# Altium schematic sheet Output_Terminals.SchDoc("Output terminals")
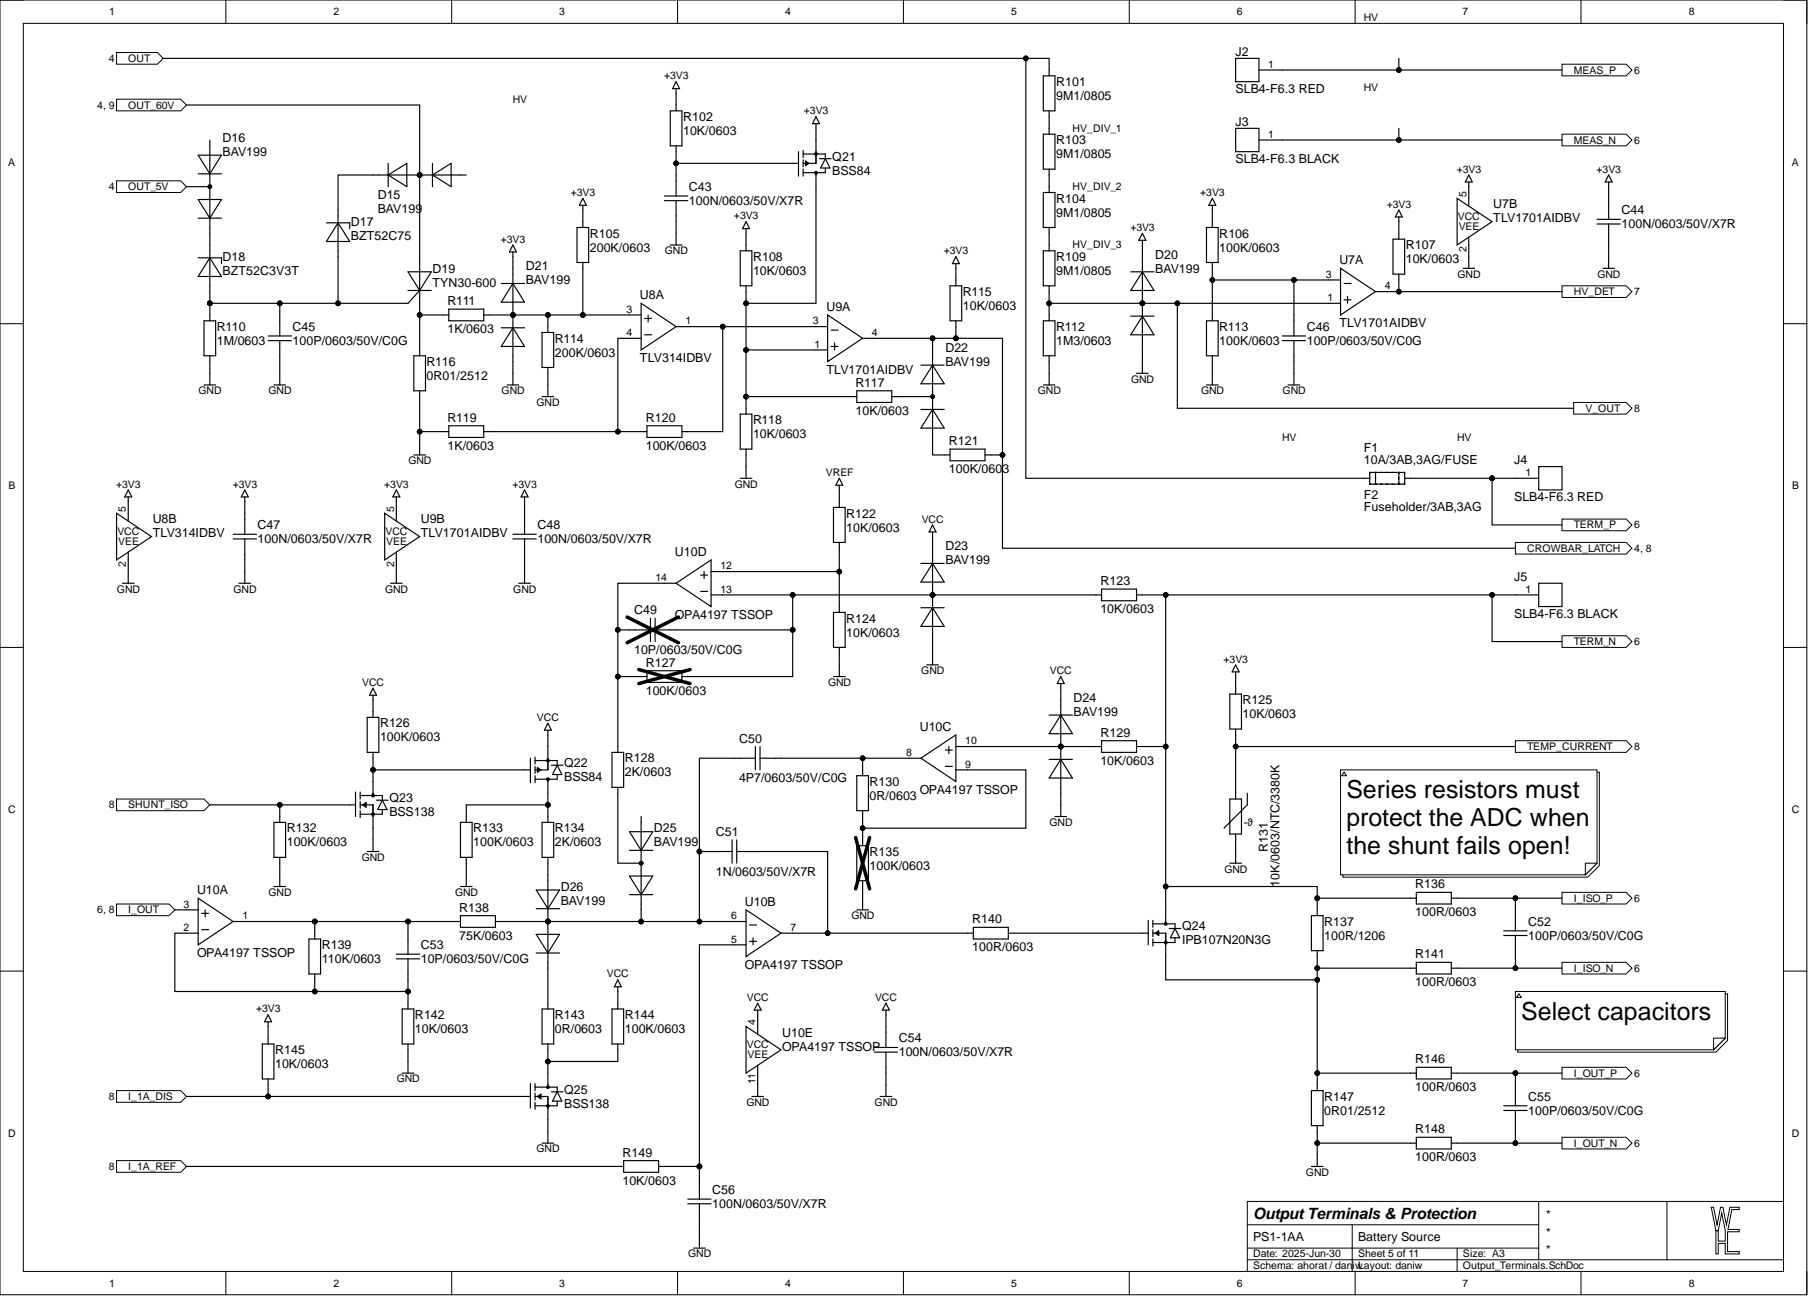
[diagram p0: Output_Terminals.SchDoc]

## Parts
C43  100N/0603/50V/X7R  [CAP0603_X7R]
C44  100N/0603/50V/X7R  [CAP0603_X7R]
C45  100P/0603/50V/C0G  [CAP0603_C0G]
C46  100P/0603/50V/C0G  [CAP0603_C0G]
C47  100N/0603/50V/X7R  [CAP0603_X7R]
C48  100N/0603/50V/X7R  [CAP0603_X7R]
C49  10P/0603/50V/C0G  [CAP0603_C0G]
C50  4P7/0603/50V/C0G  [CAP0603_C0G]
C51  1N/0603/50V/X7R  [CAP0603_X7R]
C52  100P/0603/50V/C0G  [CAP0603_C0G]
C53  10P/0603/50V/C0G  [CAP0603_C0G]
C54  100N/0603/50V/X7R  [CAP0603_X7R]
C55  100P/0603/50V/C0G  [CAP0603_C0G]
C56  100N/0603/50V/X7R  [CAP0603_X7R]
D15  BAV199  [SOT2395P280X125-3-SE]
D16  BAV199  [SOT2395P280X125-3-SE]
D17  BZT52C75  [SOD3718X125]
D18  BZT52C3V3T  [SOD1608X065]
D19  TYN30-600  [TO220-3]
D20  BAV199  [SOT2395P280X125-3-SE]
D21  BAV199  [SOT2395P280X125-3-SE]
D22  BAV199  [SOT2395P280X125-3-SE]
D23  BAV199  [SOT2395P280X125-3-SE]
D24  BAV199  [SOT2395P280X125-3-SE]
D25  BAV199  [SOT2395P280X125-3-SE]
D26  BAV199  [SOT2395P280X125-3-SE]
F1  10A/3AB,3AG/FUSE
F2  Fuseholder/3AB,3AG  [03540101ZXGY]
J2  SLB4-F6.3 RED  [SLB4-F6.3-RED]
J3  SLB4-F6.3 BLACK  [SLB4-F6.3-BLACK]
J4  SLB4-F6.3 RED  [SLB4-F6.3-RED]
J5  SLB4-F6.3 BLACK  [SLB4-F6.3-BLACK]
Q21  BSS84  [SOT2395P280X125-3-SE]
Q22  BSS84  [SOT2395P280X125-3-SE]
Q23  BSS138  [SOT2395P280X125-3-SE]
Q24  IPB107N20N3G  [TO254P1530X1035-3]
Q25  BSS138  [SOT2395P280X125-3-SE]
R101  9M1/0805  [R0805-0.50-WW]
R102  10K/0603  [R0603-0.40-WW]
R103  9M1/0805  [R0805-0.50-WW]
R104  9M1/0805  [R0805-0.50-WW]
R105  200K/0603  [R0603-0.40-WW]
R106  100K/0603  [R0603-0.40-WW]
R107  10K/0603  [R0603-0.40-WW]
R108  10K/0603  [R0603-0.40-WW]
R109  9M1/0805  [R0805-0.50-WW]
R110  1M/0603  [R0603-0.40-WW]
R111  1K/0603  [R0603-0.40-WW]
R112  1M3/0603  [R0603-0.40-WW]
R113  100K/0603  [R0603-0.40-WW]
R114  200K/0603  [R0603-0.40-WW]
R115  10K/0603  [R0603-0.40-WW]
R116  0R01/2512  [R2512-0.65-WW]
R117  10K/0603  [R0603-0.40-WW]
R118  10K/0603  [R0603-0.40-WW]
R119  1K/0603  [R0603-0.40-WW]
R120  100K/0603  [R0603-0.40-WW]
R121  100K/0603  [R0603-0.40-WW]
R122  10K/0603  [R0603-0.40-WW]
R123  10K/0603  [R0603-0.40-WW]
R124  10K/0603  [R0603-0.40-WW]
R125  10K/0603  [R0603-0.40-WW]
R126  100K/0603  [R0603-0.40-WW]
R127  100K/0603  [R0603-0.40-WW]
R128  2K/0603  [R0603-0.40-WW]
R129  10K/0603  [R0603-0.40-WW]
R130  0R/0603  [R0603-0.40-WW]
R131  10K/0603/NTC/3380K  [R0603-0.40-WW]
R132  100K/0603  [R0603-0.40-WW]
R133  100K/0603  [R0603-0.40-WW]
R134  2K/0603  [R0603-0.40-WW]
R135  100K/0603  [R0603-0.40-WW]
R136  100R/0603  [R0603-0.40-WW]
R137  100R/1206  [R1206-0.80-WW]
R137
R138  75K/0603  [R0603-0.40-WW]
R139  110K/0603  [R0603-0.40-WW]
R140  100R/0603  [R0603-0.40-WW]
R141  100R/0603  [R0603-0.40-WW]
R142  10K/0603  [R0603-0.40-WW]
R143  0R/0603  [R0603-0.40-WW]
R144  100K/0603  [R0603-0.40-WW]
R145  10K/0603  [R0603-0.40-WW]
R146  100R/0603  [R0603-0.40-WW]
R147  0R01/2512  [R2512-0.65-WW]
R148  100R/0603  [R0603-0.40-WW]
R149  10K/0603  [R0603-0.40-WW]
U7  TLV1701AIDBV  [SOT2395P280X125-5-NW]  (parts A,B)
U8  TLV314IDBV  [SOT2395P280X125-5-NW]  (parts A,B)
U9  TLV1701AIDBV  [SOT2395P280X125-5-NW]  (parts A,B)
U10  OPA4197 TSSOP  [SOP065P640X120-14NW]  (parts A,B,C,D,E)

## Ports
CROWBAR_LATCH, HV_DET, I_1A_DIS, I_1A_REF, I_ISO_N, I_ISO_P, I_OUT, I_OUT_N, I_OUT_P, MEAS_N, MEAS_P, OUT, OUT_5V, OUT_60V, SHUNT_ISO, TEMP_CURRENT, TERM_N, TERM_P, V_OUT

## Nets
+3V3: C44.1 C47.1 C48.1 D20.2 D21.2 Q21.2 R102.1 R105.1 R106.1 R107.1 R108.1 R115.2 R125.1 R145.1 U7.5 U8.5 U9.5
GND: C43.2 C44.2 C45.2 C46.2 C47.2 C48.2 C54.2 C56.2 D20.1 D21.1 D23.1 D24.1 Q23.2 Q25.2 R110.2 R112.2 R113.2 R114.2 R116.2 R118.2 R119.2 R124.2 R131.2 R132.2 R133.2 R135.2 R142.2 R147.2 R148.1 U7.2 U8.2 U9.2 U10.11
VCC: C54.1 D23.2 D24.2 Q22.2 R126.1 R144.1 U10.4
VREF: R122.1
CROWBAR_LATCH: D22.2 R115.1 R121.2 U9.4 ; port CROWBAR_LATCH
HV_DET: R107.2 U7.4 ; port HV_DET
I_1A_DIS: Q25.1 R145.2 ; port I_1A_DIS
I_1A_REF: R149.1 ; port I_1A_REF
I_ISO_N: C52.2 R141.2 ; port I_ISO_N
I_ISO_P: C52.1 R136.2 ; port I_ISO_P
I_OUT: U10.3 ; port I_OUT
I_OUT_N: C55.2 R148.2 ; port I_OUT_N
I_OUT_P: C55.1 R146.2 ; port I_OUT_P
MEAS_N: J3.1 ; port MEAS_N
MEAS_P: J2.1 ; port MEAS_P
OUT: F2.1 R101.1 ; port OUT
OUT_5V: D16.3 ; port OUT_5V
OUT_60V: D15.3 D19.2 ; port OUT_60V
SHUNT_ISO: Q23.1 R132.1 ; port SHUNT_ISO
TEMP_CURRENT: R125.2 R131.1 ; port TEMP_CURRENT
TERM_N: J5.1 Q24.3 R123.2 R129.2 R136.1 R137.1 ; port TERM_N
TERM_P: F2.2 J4.1 ; port TERM_P
V_OUT: D20.3 R109.2 R112.1 U7.1 ; port V_OUT
NetC43_1: C43.1 Q21.1 R102.2
NetC45_1: C45.1 D17.2 D18.2 D19.3 R110.1
NetC46_1: C46.1 R106.2 R113.1 U7.3
NetC49_1: C49.1 R127.1 R128.1 U10.14
NetC49_2: C49.2 D23.3 R123.1 R127.2 U10.13
NetC50_1: C50.1 C51.1 D25.2 D26.3 R138.2 U10.6
NetC50_2: C50.2 R130.1 U10.8
NetC51_2: C51.2 R140.1 U10.7
NetC53_1: C53.1 R138.1 R139.1 U10.1
NetC53_2: C53.2 R139.2 R142.1 U10.2
NetC56_1: C56.1 R149.2 U10.5
NetD15_1: D15.1
NetD15_2: D15.2 D17.1
NetD16_1: D16.1
NetD16_2: D16.2 D18.1
NetD19_1: D19.1 R111.1 R116.1
NetD21_3: D21.3 R105.2 R111.2 R114.1 U8.3
NetD22_1: D22.1 R121.1
NetD22_3: D22.3 R117.2
NetD24_3: D24.3 R129.1 U10.10
NetD25_1: D25.1
NetD25_3: D25.3 R128.2
NetD26_1: D26.1 R134.2
NetD26_2: D26.2 R143.1
NetQ21_3: Q21.3 R108.2 R117.1 R118.1 U9.1
NetQ22_1: Q22.1 Q23.3 R126.2
NetQ22_3: Q22.3 R133.1 R134.1
NetQ24_1: Q24.1 R140.2
NetQ24_2: Q24.2 R137.2 R141.1 R146.1 R147.1
NetQ25_3: Q25.3 R143.2 R144.2
NetR101_2: R101.2 R103.1
NetR103_2: R103.2 R104.1
NetR104_2: R104.2 R109.1
NetR119_1: R119.1 R120.2 U8.4
NetR120_1: R120.1 U8.1 U9.3
NetR122_2: R122.2 R124.1 U10.12
NetR130_2: R130.2 R135.1 U10.9

## Library data of the parts
C43 C44 C47 C48 C54 C56 = 100N/0603/50V/X7R: CAP SM 0603 100nF 50V X7R; Manufacturer: GENERIC; Manufacturer 1: YAGEO; Manufacturer Part Number 1: CC0603KPX7R9BB104; Absolute Value: 1E-7; Database Table Name: C$; Eurocircuits GPN: GPC0603104; Component Kind: Standard; HelpURL: https://www.yageo.com/upload/media/product/app/datasheet/mlcc/upy-gphc_x7r_6_3v-to-250v.pdf; JLC Type: Basic; Manufacturer 2: YAGEO; Manufacturer Part Number 2: CC0603MRX7R9BB104; Manufacturer Part Number 3: CC0603KRX7R9BB104; Package: 0603; Part Number: 100N/0603/50V/X7R; Supplier 1: Digi-Key; Supplier 2: Digi-Key; Supplier 3: LCSC; Supplier Part Number 1: 311-3567-1-ND; Supplier Part Number 2: 311-4110-1-ND; Supplier Part Number 3: C14663; Value: 100nF; Library Name: daniw_altium_lib.DbLib
C45 C46 C52 C55 = 100P/0603/50V/C0G: CAP SM 0603 100pF 50V C0G; Manufacturer: GENERIC; Manufacturer 1: YAGEO; Manufacturer Part Number 1: CC0603JRNPO9BN101; Absolute Value: 1E-10; Database Table Name: C$; Eurocircuits GPN: GPC0603101-C0G; Component Kind: Standard; HelpURL: https://www.yageo.com/upload/media/product/productsearch/datasheet/mlcc/UPY-GP_NP0_16V-to-50V_18.pdf; JLC Type: Basic; Manufacturer 2: YAGEO; Manufacturer Part Number 2: CC0603KRNPO9BN101; Manufacturer Part Number 3: CL10C101JB8NNNC; Package: 0603; Part Number: 100P/0603/50V/C0G; Supplier 1: Digi-Key; Supplier 2: Digi-Key; Supplier 3: LCSC; Supplier Part Number 1: 311-1069-1-ND; Supplier Part Number 2: 311-3600-1-ND; Supplier Part Number 3: C14858; Value: 100pF; Library Name: daniw_altium_lib.DbLib
C49 C53 = 10P/0603/50V/C0G: CAP SM 0603 10pF 50V C0G; Manufacturer: GENERIC; Manufacturer 1: YAGEO; Manufacturer Part Number 1: CC0603JRNPO9BN100; Absolute Value: 1E-11; Database Table Name: C$; Eurocircuits GPN: GPC0603100-C0G; Component Kind: Standard; HelpURL: https://www.yageo.com/upload/media/product/productsearch/datasheet/mlcc/UPY-GP_NP0_16V-to-50V_18.pdf; Manufacturer 2: YAGEO; Manufacturer Part Number 2: CC0603KRNPO9BN100; Manufacturer Part Number 3: CL10C100JB8NNNC; Package: 0603; Part Number: 10P/0603/50V/C0G; Supplier 1: Digi-Key; Supplier 2: Digi-Key; Supplier 3: LCSC; Supplier Part Number 1: 311-1058-1-ND; Supplier Part Number 2: 311-4025-1-ND; Supplier Part Number 3: C1634; Value: 10pF; Library Name: daniw_altium_lib.DbLib
C50 = 4P7/0603/50V/C0G: CAP SM 0603 4.7pF 50V C0G; Manufacturer: GENERIC; Manufacturer 1: YAGEO; Manufacturer Part Number 1: CC0603CRNPO9BN4R7; Absolute Value: 4.7E-12; Database Table Name: C$; Eurocircuits GPN: GPC06034R7-C0G; Component Kind: Standard; HelpURL: https://www.yageo.com/upload/media/product/productsearch/datasheet/mlcc/UPY-GP_NP0_16V-to-50V_18.pdf; Manufacturer 2: YAGEO; Manufacturer Part Number 2: CC0603DRNPO9BN4R7; Manufacturer Part Number 3: CGA0603C0G4R7C500JT; Package: 0603; Part Number: 4P7/0603/50V/C0G; Supplier 1: Digi-Key; Supplier 2: Digi-Key; Supplier 3: LCSC; Supplier Part Number 1: 311-1740-1-ND; Supplier Part Number 2: 13-CC0603DRNPO9BN4R7CT-ND; Supplier Part Number 3: C41361238; Value: 4.7pF; Library Name: daniw_altium_lib.DbLib
C51 = 1N/0603/50V/X7R: CAP SM 0603 1.0nF 50V X7R; Manufacturer: GENERIC; Manufacturer 1: YAGEO; Manufacturer Part Number 1: CC0603KPX7R9BB102; Absolute Value: 1E-9; Database Table Name: C$; Eurocircuits GPN: GPC0603102; Component Kind: Standard; HelpURL: https://www.yageo.com/upload/media/product/app/datasheet/mlcc/upy-gphc_x7r_6_3v-to-250v.pdf; JLC Type: Basic; Manufacturer 2: YAGEO; Manufacturer Part Number 2: CC0603KRX7R9BB102; Manufacturer Part Number 3: CL10B102KB8NNNC; Package: 0603; Part Number: 1N/0603/50V/X7R; Supplier 1: Digi-Key; Supplier 2: Digi-Key; Supplier 3: LCSC; Supplier Part Number 1: 13-CC0603KPX7R9BB102CT-ND; Supplier Part Number 2: 311-1080-1-ND; Supplier Part Number 3: C1588; Value: 1.0nF; Library Name: daniw_altium_lib.DbLib
D15 D16 D20-D26 = BAV199: Silicon Low Leakage Diode 85V; Manufacturer: Infineon Technologies; Manufacturer Part Number 1: BAV199E6433HTMA1; Database Table Name: D$; Absolute Value: 85V; Component Kind: Standard; HelpURL: https://www.infineon.com/dgdl/bav199series.pdf?folderId=db3a30431400ef6801141c748874044e&fileId=db3a30431400ef6801141cba733104e5; Manufacturer 1: Infineon Technologies; Manufacturer 3: LRC; Manufacturer Part Number 3: LBAV199LT1G; Package: SOT23; Part Number: BAV199; Supplier 1: Digikey; Supplier 3: LCSC; Supplier Part Number 1: BAV199E6433HTMA1CT-ND; Supplier Part Number 3: C12740; Value: BAV199; Library Name: daniw_altium_lib.DbLib
D17 = BZT52C75: DIODE ZENER 74.5V 350MW SOD123; Manufacturer: Nexperia USA Inc.; Manufacturer Part Number 1: BZT52-C75J; Database Table Name: D$; Absolute Value: 74.5 V; Component Kind: Standard; HelpURL: https://assets.nexperia.com/documents/data-sheet/BZT52_SER.pdf; Manufacturer 1: Nexperia USA Inc.; Manufacturer 2: Panjit International Inc.; Manufacturer Part Number 2: BZT52-C75-AU_R1_000A1; Package: SOD-123; Part Number: BZT52C75; Supplier 1: Digikey; Supplier 2: Digikey; Supplier Part Number 1: 1727-8146-1-ND; Supplier Part Number 2: 3757-BZT52-C75-AU_R1_000A1CT-ND; Value: BZT52C75; Library Name: daniw_altium_lib.DbLib
D18 = BZT52C3V3T: DIODE ZENER 3.3V 300MW SOD523; Manufacturer: Diodes Incorporated; Manufacturer Part Number 1: BZT52C3V3T-7; Database Table Name: D$; Absolute Value: 3.3 V; Component Kind: Standard; HelpURL: https://www.diodes.com/assets/Datasheets/ds30502.pdf; Manufacturer 1: Diodes Incorporated; Manufacturer 2: Micro Commercial Co; Manufacturer 3: BORN; Manufacturer Part Number 2: BZT52C3V3T-TP; Manufacturer Part Number 3: BZT52C3V3T; Package: SC-79, SOD-523; Part Number: BZT52C3V3T; Supplier 1: Digikey; Supplier 2: Digikey; Supplier 3: LCSC; Supplier Part Number 1: BZT52C3V3T-7DICT-ND; Supplier Part Number 2: BZT52C3V3T-TPMSCT-ND; Supplier Part Number 3: C20612286; Value: BZT52C3V3T; Library Name: daniw_altium_lib.DbLib
D19 = TYN30-600: Planar passivated Silicon Controlled Rectifier (SCR) in a TO220 plastic package, 600V, 30A; Manufacturer: WeEn Semiconductors; Manufacturer Part Number 1: TYN30-600TFQ; Database Table Name: D$; Value: TYN30-600TFQ; Component Kind: Standard; HelpURL: https://www.ween-semi.com/sites/default/files/2022-02/TYN30-600TF.pdf; Manufacturer 1: WeEn Semiconductors; Package: TO-220; Part Number: TYN30-600; Supplier 1: Digikey; Supplier Part Number 1: 1740-TYN30-600TFQ-ND; Library Name: daniw_altium_lib.DbLib
F1 = 10A/3AB,3AG/FUSE: Fuse 600V AC/DC 3AB, 3AG; Manufacturer: Belfuse; Manufacturer 1: Bel Fuse Inc.; Manufacturer Part Number 1: 0ADEC9100-BE; Database Table Name: R$; Value: 10A; Component Kind: Standard; HelpURL: https://www.belfuse.com/resources/datasheets/circuitprotection/ds-cp-0adex-series.pdf; Package: 3AB,3AG; Part Number: 10A/3AB,3AG/FUSE; Supplier 1: Digi-Key; Supplier Part Number 1: 5923-0ADEC9100-BE-ND; Library Name: daniw_altium_lib.DbLib
F2 = Fuseholder/3AB,3AG: 354 Series OMNI-BLOK® Molded Base Fuse Block for 3AB/3AG Fuses; Manufacturer: Littelfuse; Manufacturer 1: Littelfuse Inc.; Database Table Name: R$; Component Kind: Standard; HelpURL: https://www.littelfuse.com/assetdocs/littelfuse_fuse_block_354_datasheet.pdf?assetguid=6e94c133-ad48-47b7-8fff-80ea6c66704d; Manufacturer Part Number 1: 03540101ZXGY; Package: 3AB,3AG; Part Number: Fuseholder/3AB,3AG; Supplier 1: Digi-Key; Supplier Part Number 1: F1498-ND; Library Name: daniw_altium_lib.DbLib
J2 J4 = SLB4-F6.3 RED: SLB4-F6.3 Insulated, rigid, Ø 4 mm, accepting spring-loaded Ø 4 mm plugs with rigid insulating sleeve. Machined brass. Insulation material UL94 V-0. The socket is screwed into pre-drilled panels of plastic, metal, etc. Flat connecting tab 6.3 mm x 0.8 mm.; Manufacturer: Stäubli; Manufacturer 1: Stäubli Electrical Connectors; Manufacturer Part Number 1: 23.3060-22; Database Table Name: CON$; System: Connector; Component Kind: Standard; HelpURL: https://www.staubli.com/content/dam/ecs/technical-documentation/datasheets/TM/23.3060_en.pdf; Part Number: SLB4-F6.3 RED; Supplier 1: Distrelec; Supplier Part Number 1: 30136218; Library Name: daniw_altium_lib.DbLib
J3 J5 = SLB4-F6.3 BLACK: SLB4-F6.3 Insulated, rigid, Ø 4 mm, accepting spring-loaded Ø 4 mm plugs with rigid insulating sleeve. Machined brass. Insulation material UL94 V-0. The socket is screwed into pre-drilled panels of plastic, metal, etc. Flat connecting tab 6.3 mm x 0.8 mm.; Manufacturer: Stäubli; Manufacturer 1: Stäubli Electrical Connectors; Manufacturer Part Number 1: 23.3060-21; Database Table Name: CON$; System: Connector; Component Kind: Standard; HelpURL: https://www.staubli.com/content/dam/ecs/technical-documentation/datasheets/TM/23.3060_en.pdf; Part Number: SLB4-F6.3 BLACK; Supplier 1: Distrelec; Supplier Part Number 1: 30136217; Library Name: daniw_altium_lib.DbLib
Q21 Q22 = BSS84: 50V P-Channel MOSFET; Manufacturer: GENERIC; Manufacturer 1: Good-Ark Semiconductor; Database Table Name: T$; Component Kind: Standard; HelpURL: https://goodarksemi.com/docs/datasheets/mosfets/BSS84.pdf; JLC Type: Extended; Manufacturer 2: LRC; Manufacturer 3: Jiangsu Changjing Electronics Technology Co., Ltd.; Manufacturer Part Number 1: BSS84; Manufacturer Part Number 2: LBSS84LT1G; Manufacturer Part Number 3: BSS84; Package: SOT23; Part Number: BSS84; Supplier 1: Digi-Key; Supplier 2: LCSC; Supplier 3: LCSC; Supplier Part Number 1: 4786-BSS84CT-ND; Supplier Part Number 2: C8492; Supplier Part Number 3: C114481; Library Name: daniw_altium_lib.DbLib
Q23 Q25 = BSS138: N-Kanal 50 V 220mA (Ta) 350mW (Ta) Oberflächenmontage SOT-23; Manufacturer: GENERIC; Manufacturer 1: ANBON SEMICONDUCTOR (INT'L) LIMITED; Database Table Name: T$; Component Kind: Standard; HelpURL: https://mm.digikey.com/Volume0/opasdata/d220001/medias/docus/3037/BSS138.pdf; JLC Type: Extended; Manufacturer 2: FOSAN; Manufacturer 3: FOSAN; Manufacturer Part Number 1: BSS138; Manufacturer Part Number 2: BSS138; Manufacturer Part Number 3: BSS138; Package: SOT23; Part Number: BSS138; Supplier 1: Digi-Key; Supplier 2: LCSC; Supplier 3: LCSC; Supplier Part Number 1: 4530-BSS138CT-ND; Supplier Part Number 2: C2926186; Supplier Part Number 3: C2926186; Library Name: daniw_altium_lib.DbLib
Q24 = IPB107N20N3G: 200V 88A 300W 10.7mΩ@10V,88A 4V 1 N-channel TO-263-3 MOSFETs ROHS; Manufacturer: Infineon Technologies; Manufacturer Part Number 1: IPB107N20N3GATMA1CT-ND; Database Table Name: T$; Component Kind: Standard; HelpURL: https://www.infineon.com/dgdl/IPP_I_110N20N3+G_IPB107N20N3+G+Rev2.2.pdf?folderId=db3a3043163797a6011637d4bae7003b&fileId=db3a3043243b5f170124968e7d1f18e7; Manufacturer 1: Infineon Technologies; Manufacturer 3: Infineon Technologies; Manufacturer Part Number 3: IPB107N20N3G; Package: TO-263; Part Number: IPB107N20N3G; Supplier 1: Digi-Key; Supplier 3: LCSC; Supplier Part Number 1: IPB107N20N3GATMA1; Supplier Part Number 3: C444895; Library Name: daniw_altium_lib.DbLib
R101 R103 R104 R109 = 9M1/0805: RES SM 0805 9M1; Manufacturer: GENERIC; Manufacturer 1: YAGEO; Manufacturer Part Number 1: RC0805FR-079M1L; Absolute Value: 9100000; Database Table Name: R$; Eurocircuits GPN: GPR08059M1; Component Kind: Standard; HelpURL: https://www.yageo.com/upload/media/product/productsearch/datasheet/rchip/PYu-RC_Group_51_RoHS_L_12.pdf; Manufacturer 3: FOJAN; Manufacturer Part Number 3: FRC0805J915TS; Package: 0805; Part Number: 9M1/0805; Supplier 1: Digi-Key; Supplier 3: LCSC; Supplier Part Number 1: 13-RC0805FR-079M1LCT-ND; Supplier Part Number 3: C2930318; Value: 9M1; Library Name: daniw_altium_lib.DbLib
R102 R107 R108 R115 R117 R118 R122-R125 R129 R142 R145 R149 = 10K/0603: RES SM 0603 10K; Manufacturer: GENERIC; Manufacturer 1: YAGEO; Manufacturer Part Number 1: RC0603FR-1310KL; Absolute Value: 10000; Database Table Name: R$; Eurocircuits GPN: GPR060310K; Component Kind: Standard; HelpURL: https://www.yageo.com/upload/media/product/productsearch/datasheet/rchip/PYu-RC_Group_51_RoHS_L_12.pdf; JLC Type: Basic; Manufacturer 2: YAGEO; Manufacturer 3: UNI-ROYAL(Uniroyal Elec); Manufacturer Part Number 2: RC0603FR-1010KL; Manufacturer Part Number 3: 0603WAF1002T5E; Package: 0603; Part Number: 10K/0603; Supplier 1: Digi-Key; Supplier 2: Digi-Key; Supplier 3: LCSC; Supplier Part Number 1: 13-RC0603FR-1310KLCT-ND; Supplier Part Number 2: 13-RC0603FR-1010KLCT-ND; Supplier Part Number 3: C25804; Value: 10K; Library Name: daniw_altium_lib.DbLib
R105 R114 = 200K/0603: RES SM 0603 200K; Manufacturer: GENERIC; Manufacturer 1: YAGEO; Manufacturer Part Number 1: RC0603FR-13200KL; Absolute Value: 200000; Database Table Name: R$; Eurocircuits GPN: GPR0603200K; Component Kind: Standard; HelpURL: https://www.yageo.com/upload/media/product/app/datasheet/rchip/pyu-rc_group_51_rohs_l.pdf; JLC Type: Basic; Manufacturer 2: YAGEO; Manufacturer 3: UNI-ROYAL(Uniroyal Elec); Manufacturer Part Number 2: RC0603FR-10200KL; Manufacturer Part Number 3: 0603WAF2003T5E; Package: 0603; Part Number: 200K/0603; Supplier 1: Digi-Key; Supplier 2: Digi-Key; Supplier 3: LCSC; Supplier Part Number 1: 13-RC0603FR-13200KLCT-ND; Supplier Part Number 2: 13-RC0603FR-10200KLCT-ND; Supplier Part Number 3: C25811; Value: 200K; Library Name: daniw_altium_lib.DbLib
R106 R113 R120 R121 R126 R127 R132 R133 R135 R144 = 100K/0603: RES SM 0603 100K; Manufacturer: GENERIC; Manufacturer 1: YAGEO; Manufacturer Part Number 1: RC0603FR-13100KL; Absolute Value: 100000; Database Table Name: R$; Eurocircuits GPN: GPR0603100K; Component Kind: Standard; HelpURL: https://www.yageo.com/upload/media/product/productsearch/datasheet/rchip/PYu-RC_Group_51_RoHS_L_12.pdf; JLC Type: Basic; Manufacturer 2: YAGEO; Manufacturer 3: UNI-ROYAL(Uniroyal Elec); Manufacturer Part Number 2: RC0603FR-10100KL; Manufacturer Part Number 3: 0603WAF1003T5E; Package: 0603; Part Number: 100K/0603; Supplier 1: Digi-Key; Supplier 2: Digi-Key; Supplier 3: LCSC; Supplier Part Number 1: 13-RC0603FR-13100KLCT-ND; Supplier Part Number 2: 13-RC0603FR-10100KLCT-ND; Supplier Part Number 3: C25803; Value: 100K; Library Name: daniw_altium_lib.DbLib
R110 = 1M/0603: RES SM 0603 1M; Manufacturer: GENERIC; Manufacturer 1: YAGEO; Manufacturer Part Number 1: RC0603FR-131ML; Absolute Value: 1000000; Database Table Name: R$; Eurocircuits GPN: GPR06031M; Component Kind: Standard; HelpURL: https://www.yageo.com/upload/media/product/app/datasheet/rchip/pyu-rc_group_51_rohs_l.pdf; JLC Type: Basic; Manufacturer 2: YAGEO; Manufacturer 3: UNI-ROYAL(Uniroyal Elec); Manufacturer Part Number 2: RC0603FR-101ML; Manufacturer Part Number 3: 0603WAF1004T5E; Package: 0603; Part Number: 1M/0603; Supplier 1: Digi-Key; Supplier 2: Digi-Key; Supplier 3: LCSC; Supplier Part Number 1: 13-RC0603FR-131MLCT-ND; Supplier Part Number 2: 13-RC0603FR-101MLCT-ND; Supplier Part Number 3: C22935; Value: 1M; Library Name: daniw_altium_lib.DbLib
R111 R119 = 1K/0603: RES SM 0603 1K; Manufacturer: GENERIC; Manufacturer 1: YAGEO; Manufacturer Part Number 1: RC0603FR-131KL; Absolute Value: 1000; Database Table Name: R$; Eurocircuits GPN: GPR06031K; Component Kind: Standard; HelpURL: https://www.yageo.com/upload/media/product/app/datasheet/rchip/pyu-rc_group_51_rohs_l.pdf; JLC Type: Basic; Manufacturer 2: YAGEO; Manufacturer 3: UNI-ROYAL(Uniroyal Elec); Manufacturer Part Number 2: RC0603FR-101KL; Manufacturer Part Number 3: 0603WAF1001T5E; Package: 0603; Part Number: 1K/0603; Supplier 1: Digi-Key; Supplier 2: Digi-Key; Supplier 3: LCSC; Supplier Part Number 1: 13-RC0603FR-131KLCT-ND; Supplier Part Number 2: 13-RC0603FR-101KLCT-ND; Supplier Part Number 3: C21190; Value: 1K; Library Name: daniw_altium_lib.DbLib
R112 = 1M3/0603: RES SM 0603 1M3; Manufacturer: GENERIC; Manufacturer 1: YAGEO; Manufacturer Part Number 1: RC0603FR-071M3L; Absolute Value: 1300000; Database Table Name: R$; Eurocircuits GPN: GPR06031M3; Component Kind: Standard; HelpURL: https://www.yageo.com/upload/media/product/app/datasheet/rchip/pyu-rc_group_51_rohs_l.pdf; Manufacturer 3: FOJAN; Manufacturer Part Number 3: FRC0603J135; Package: 0603; Part Number: 1M3/0603; Supplier 1: Digi-Key; Supplier 3: LCSC; Supplier Part Number 1: YAG3326CT-ND; Supplier Part Number 3: C2907082; Value: 1M3; Library Name: daniw_altium_lib.DbLib
R116 R147 = 0R01/2512: RES SM 2512 0R01; Manufacturer: GENERIC; Manufacturer 1: YAGEO; Manufacturer Part Number 1: PE2512FKE7W0R01L; Absolute Value: 0.01; Database Table Name: R$; Component Kind: Standard; HelpURL: https://www.yageo.com/upload/media/product/products/datasheet/rchip/PYu-PE_521_RoHS_L_13.pdf; Manufacturer 3: prosemi; Manufacturer Part Number 3: LMJ25MF2P0R010; Package: 2512; Part Number: 0R01/2512; Supplier 1: Digi-Key; Supplier 3: LCSC; Supplier Part Number 1: YAG2167CT-ND; Supplier Part Number 3: C2838941; Value: 0R01; Library Name: daniw_altium_lib.DbLib
R128 R134 = 2K/0603: RES SM 0603 2K; Manufacturer: GENERIC; Manufacturer 1: YAGEO; Manufacturer Part Number 1: RC0603FR-132KL; Absolute Value: 2000; Database Table Name: R$; Eurocircuits GPN: GPR06032K; Component Kind: Standard; HelpURL: https://www.yageo.com/upload/media/product/app/datasheet/rchip/pyu-rc_group_51_rohs_l.pdf; JLC Type: Basic; Manufacturer 2: YAGEO; Manufacturer 3: UNI-ROYAL(Uniroyal Elec); Manufacturer Part Number 2: RC0603FR-102KL; Manufacturer Part Number 3: 0603WAF2001T5E; Package: 0603; Part Number: 2K/0603; Supplier 1: Digi-Key; Supplier 2: Digi-Key; Supplier 3: LCSC; Supplier Part Number 1: 13-RC0603FR-132KLCT-ND; Supplier Part Number 2: 13-RC0603FR-102KLCT-ND; Supplier Part Number 3: C22975; Value: 2K; Library Name: daniw_altium_lib.DbLib
R130 R143 = 0R/0603: RES SM 0603 0R; Manufacturer: GENERIC; Manufacturer 1: YAGEO; Manufacturer Part Number 1: RC0603FR-070RL; Absolute Value: 0; Database Table Name: R$; Eurocircuits GPN: GPR06030R; Component Kind: Standard; HelpURL: https://www.yageo.com/upload/media/product/app/datasheet/rchip/pyu-rc_group_51_rohs_l.pdf; JLC Type: Basic; Manufacturer 2: YAGEO; Manufacturer 3: UNI-ROYAL(Uniroyal Elec); Manufacturer Part Number 2: RC0603FR-130RL; Manufacturer Part Number 3: 0603WAF0000T5E; Package: 0603; Part Number: 0R/0603; Supplier 1: Digi-Key; Supplier 2: Digi-Key; Supplier 3: LCSC; Supplier Part Number 1: 311-0.0HRCT-ND; Supplier Part Number 2: 13-RC0603FR-130RLCT-ND; Supplier Part Number 3: C21189; Value: 0R; Library Name: daniw_altium_lib.DbLib
R131 = 10K/0603/NTC/3380K: RES NTC SM 0603 10K; Manufacturer: GENERIC; Manufacturer 1: Murata; Manufacturer Part Number 1: NCU18XH103E60RB; Absolute Value: 10000; Database Table Name: R$; Eurocircuits GPN: GPR060310K; Component Kind: Standard; HelpURL: https://www.murata.com/en-us/api/pdfdownloadapi?cate=luNTCforTempeSenso&partno=NCU18XH103E60RB; JLC Type: Extended; Manufacturer 3: Sunlord; Manufacturer Part Number 3: SDNT1608X103F3380FTF; Package: 0603; Part Number: 10K/0603/NTC/3380K; Supplier 1: Digi-Key; Supplier 3: LCSC; Supplier Part Number 1: 490-18164-1-ND; Supplier Part Number 3: C316397; Value: 10K; Library Name: daniw_altium_lib.DbLib
R136 R140 R141 R146 R148 = 100R/0603: RES SM 0603 100R; Manufacturer: GENERIC; Manufacturer 1: YAGEO; Manufacturer Part Number 1: RC0603FR-13100RL; Absolute Value: 100; Database Table Name: R$; Eurocircuits GPN: GPR0603100R; Component Kind: Standard; HelpURL: https://www.yageo.com/upload/media/product/productsearch/datasheet/rchip/PYu-RC_Group_51_RoHS_L_12.pdf; Manufacturer 2: YAGEO; Manufacturer 3: UNI-ROYAL(Uniroyal Elec); Manufacturer Part Number 2: RC0603FR-10100RL; Manufacturer Part Number 3: 0603WAF1000T5E; Package: 0603; Part Number: 100R/0603; Supplier 1: Digi-Key; Supplier 2: Digi-Key; Supplier 3: LCSC; Supplier Part Number 1: 13-RC0603FR-13100RLCT-ND; Supplier Part Number 2: 13-RC0603FR-10100RLCT-ND; Supplier Part Number 3: C22775; Value: 100R; Library Name: daniw_altium_lib.DbLib
R137 = 100R/1206: RES SM 1206 100R; Manufacturer: GENERIC; Manufacturer 1: YAGEO; Manufacturer Part Number 1: RC1206FR-07100RL; Absolute Value: 100; Database Table Name: R$; Component Kind: Standard; HelpURL: https://www.yageo.com/upload/media/product/products/datasheet/rchip/PYu-RC_Group_51_RoHS_L_12.pdf; Manufacturer 3: UNI-ROYAL(Uniroyal Elec); Manufacturer Part Number 3: 1206W4F1000T5E; Package: 1206; Part Number: 100R/1206; Supplier 1: Digi-Key; Supplier 3: LCSC; Supplier Part Number 1: 311-100FRCT-ND; Supplier Part Number 3: C17901; Value: 100R; Library Name: daniw_altium_lib.DbLib
R138 = 75K/0603: RES SM 0603 75K; Manufacturer: GENERIC; Manufacturer 1: YAGEO; Manufacturer Part Number 1: RC0603FR-1375KL; Absolute Value: 75000; Database Table Name: R$; Eurocircuits GPN: GPR060375K; Component Kind: Standard; HelpURL: https://www.yageo.com/upload/media/product/app/datasheet/rchip/pyu-rc_group_51_rohs_l.pdf; JLC Type: Basic; Manufacturer 2: YAGEO; Manufacturer 3: UNI-ROYAL(Uniroyal Elec); Manufacturer Part Number 2: RC0603FR-1075KL; Manufacturer Part Number 3: 0603WAF7502T5E; Package: 0603; Part Number: 75K/0603; Supplier 1: Digi-Key; Supplier 2: Digi-Key; Supplier 3: LCSC; Supplier Part Number 1: 13-RC0603FR-1375KLCT-ND; Supplier Part Number 2: 13-RC0603FR-1075KLCT-ND; Supplier Part Number 3: C23242; Value: 75K; Library Name: daniw_altium_lib.DbLib
R139 = 110K/0603: RES SM 0603 110K; Manufacturer: GENERIC; Manufacturer 1: YAGEO; Manufacturer Part Number 1: RC0603FR-13110KL; Absolute Value: 110000; Database Table Name: R$; Eurocircuits GPN: GPR0603110K; Component Kind: Standard; HelpURL: https://www.yageo.com/upload/media/product/productsearch/datasheet/rchip/PYu-RC_Group_51_RoHS_L_12.pdf; JLC Type: Extended; Manufacturer 2: YAGEO; Manufacturer 3: UNI-ROYAL(Uniroyal Elec); Manufacturer Part Number 2: RC0603FR-07110KL; Manufacturer Part Number 3: 0603WAF1103T5E; Package: 0603; Part Number: 110K/0603; Supplier 1: Digi-Key; Supplier 2: Digi-Key; Supplier 3: LCSC; Supplier Part Number 1: 13-RC0603FR-13110KLCT-ND; Supplier Part Number 2: 311-110KHRCT-ND; Supplier Part Number 3: C25805; Value: 110K; Library Name: daniw_altium_lib.DbLib
U7 U9 = TLV1701 SOT23: TLV1701 2.2-V to 36-V, microPower Comparato; Manufacturer: Texas Instruments; Manufacturer Part Number 1: TLV1701AIDBVR; Database Table Name: Integrated$; Component Kind: Standard; HelpURL: https://www.ti.com/lit/ds/symlink/tlv1701.pdf; Manufacturer 1: Texas Instruments; Manufacturer 2: Texas Instruments; Manufacturer 3: Texas Instruments; Manufacturer Part Number 2: TLV1701QDBVRQ1; Manufacturer Part Number 3: TLV1701AIDBVR; Package: SOT23-5; Part Number: TLV1701 SOT23; Supplier 1: Digi-Key; Supplier 2: Digi-Key; Supplier 3: LCSC; Supplier Part Number 1: 296-40599-1-ND; Supplier Part Number 2: 296-47458-1-ND; Supplier Part Number 3: C130035; Library Name: daniw_altium_lib.DbLib
U8 = TLV314 SOT23: TLV314, 3-MHz, Low-Power, Internal EMI Filter, RRIO, Operational Amplifier; Manufacturer: Texas Instruments; Manufacturer Part Number 1: TLV314IDBVR; Database Table Name: Integrated$; Component Kind: Standard; HelpURL: https://www.ti.com/lit/ds/symlink/tlv4314.pdf; Manufacturer 1: Texas Instruments; Manufacturer 2: Texas Instruments; Manufacturer 3: Texas Instruments; Manufacturer Part Number 2: TLV314IDBVT; Manufacturer Part Number 3: TLV314IDBVR; Package: SOT23-5; Part Number: TLV314 SOT23; Supplier 1: Digi-Key; Supplier 2: Digi-Key; Supplier 3: LCSC; Supplier Part Number 1: 296-46275-1-ND; Supplier Part Number 2: 296-44110-2-ND; Supplier Part Number 3: C133032; Library Name: daniw_altium_lib.DbLib
U10 = OPA4197 TSSOP: OPA4197 36-V, Precision, Rail-to-Rail Input/Output, Low Offset Voltage, Operational Amplifiers; Manufacturer: Texas Instruments; Manufacturer Part Number 1: OPA4197IPWR; Database Table Name: Integrated$; Component Kind: Standard; HelpURL: https://www.ti.com/lit/ds/symlink/opa197.pdf; Manufacturer 1: Texas Instruments; Manufacturer 2: Texas Instruments; Manufacturer 3: Texas Instruments; Manufacturer Part Number 2: OPA4197QPWRQ1; Manufacturer Part Number 3: OPA4197IPWR; Package: TSSOP-14; Part Number: OPA4197 TSSOP; Supplier 1: Digi-Key; Supplier 2: Digi-Key; Supplier 3: LCSC; Supplier Part Number 1: 296-45060-1-ND; Supplier Part Number 2: 296-OPA4197QPWRQ1CT-ND; Supplier Part Number 3: C2057327; Library Name: daniw_altium_lib.DbLib
